# Altium schematic sheet CHIPHOME Y17BP01 W5500.SchDoc
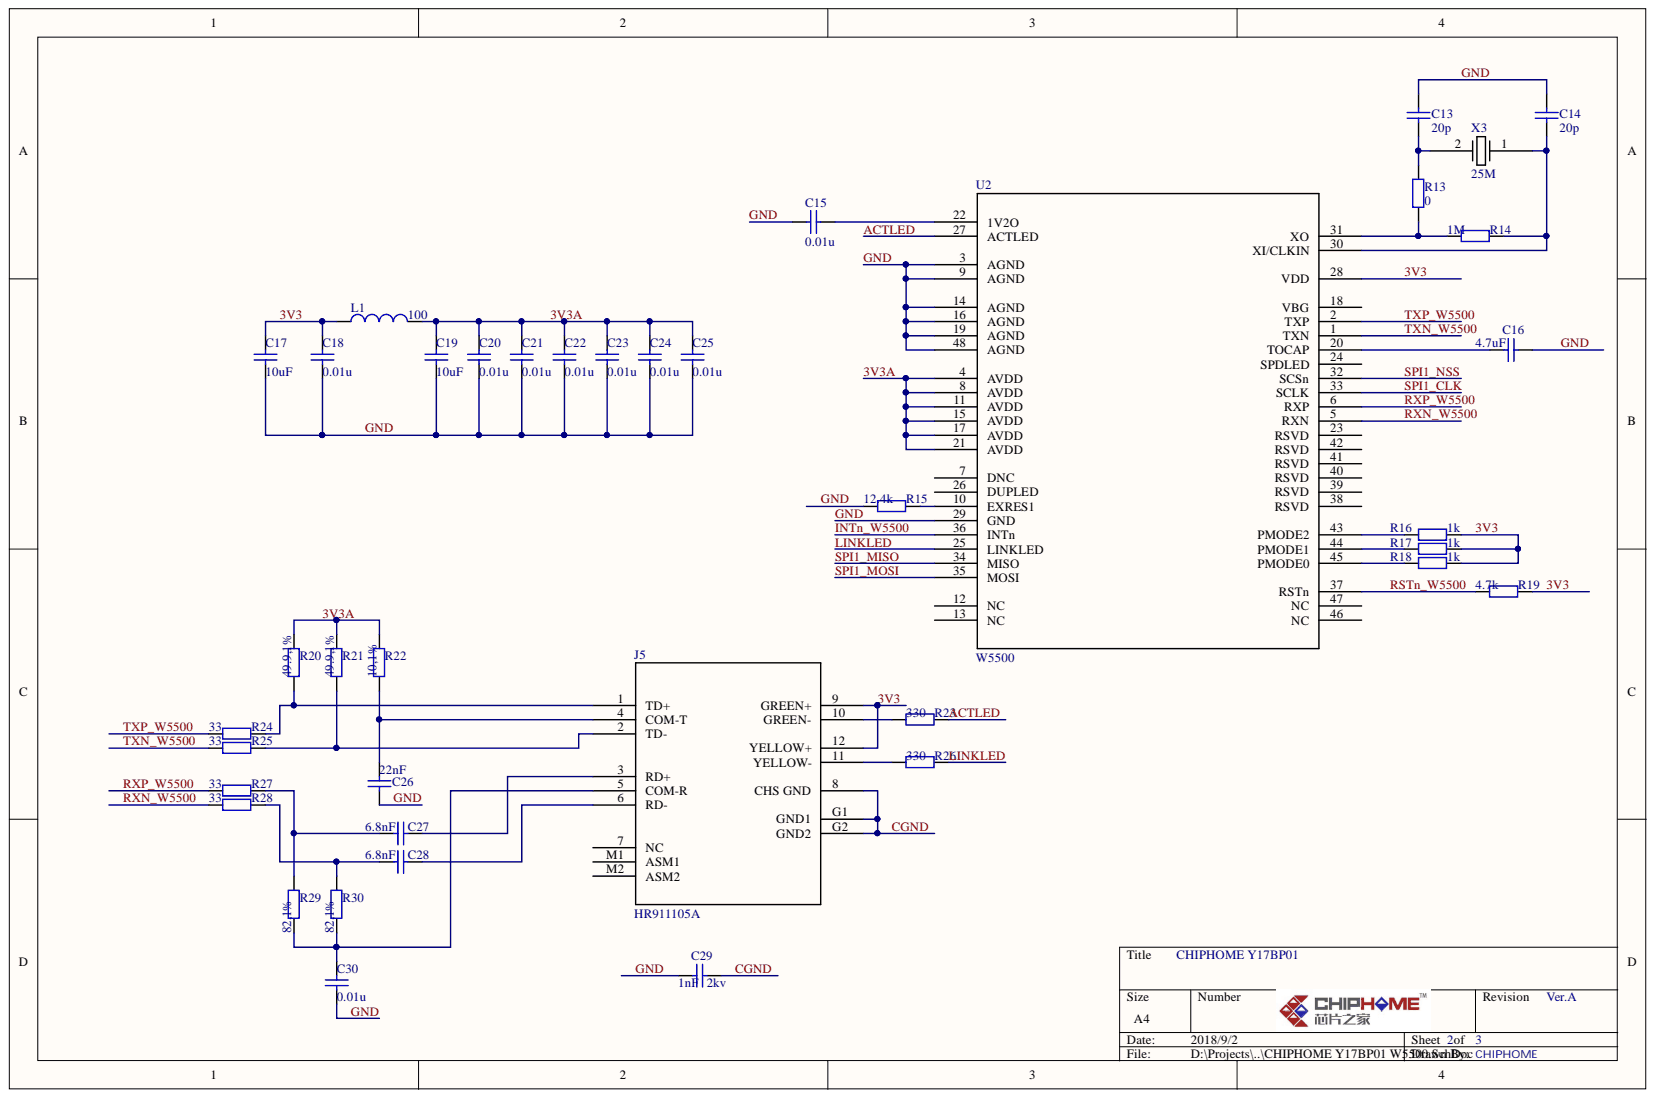
[diagram p0: CHIPHOME Y17BP01 W5500.SchDoc]

## Parts
C13  20p  [sc0603a]
C14  20p  [sc0603a]
C15  0.01u  [sc0603a]
C16  4.7uF  [sc0805a]
C17  10uF  [sc0805a]
C18  0.01u  [sc0603a]
C19  10uF  [sc0805a]
C20  0.01u  [sc0603a]
C21  0.01u  [sc0603a]
C22  0.01u  [sc0603a]
C23  0.01u  [sc0603a]
C24  0.01u  [sc0603a]
C25  0.01u  [sc0603a]
C26  22nF  [sc0603a]
C27  6.8nF  [sc0603a]
C28  6.8nF  [sc0603a]
C29  2kv  value 1nF  [sc1206a]
C30  0.01u  [sc0603a]
J5  HR911105A  [mj8-1521a]
L1  100  [sl0603a]
R13  0  [sr0603a]
R14  1M  [sr0603a]
R15  12.4k  [sr0603a]
R16  1k  [sr0603a]
R17  1k  [sr0603a]
R18  1k  [sr0603a]
R19  4.7k  [sr0603a]
R20  49.9,1%  [sr0603a]
R21  49.9,1%  [sr0603a]
R22  10,1%  [sr0603a]
R23  330  [sr0603a]
R24  33  [sr0603a]
R25  33  [sr0603a]
R26  330  [sr0603a]
R27  33  [sr0603a]
R28  33  [sr0603a]
R29  82,1%  [sr0603a]
R30  82,1%  [sr0603a]
U2  W5500  [qfp48-20-0909b]
X3  25M  [sx2-0412a]

## Nets
3V3: C17.2 C18.2 J5.9(GREEN+) J5.12(YELLOW+) L1.1 R16.1 R17.1 R18.1 R19.2 U2.28(VDD)
3V3A: C19.2 C20.2 C21.2 C22.2 C23.2 C24.2 C25.2 L1.2 R20.2 R21.2 R22.2 U2.4(AVDD) U2.8(AVDD) U2.11(AVDD) U2.15(AVDD) U2.17(AVDD) U2.21(AVDD)
ACTLED: R23.1 U2.27(ACTLED)
CGND: C29.2 J5.8(CHS_GND) J5.G1(GND1) J5.G2(GND2)
GND: C13.1 C14.1 C15.1 C16.2 C17.1 C18.1 C19.1 C20.1 C21.1 C22.1 C23.1 C24.1 C25.1 C26.2 C29.1 C30.2 R15.1 U2.3(AGND) U2.9(AGND) U2.14(AGND) U2.16(AGND) U2.19(AGND) U2.29(GND) U2.48(AGND)
INTn_W5500: U2.36(INTn)
LINKLED: R26.1 U2.25(LINKLED)
RSTn_W5500: R19.1 U2.37(RSTn)
RXN_W5500: R28.1 U2.5(RXN)
RXP_W5500: R27.1 U2.6(RXP)
SPI1_CLK: U2.33(SCLK)
SPI1_MISO: U2.34(MISO)
SPI1_MOSI: U2.35(MOSI)
SPI1_NSS: U2.32(SCSn)
TXN_W5500: R25.1 U2.1(TXN)
TXP_W5500: R24.1 U2.2(TXP)
NetC13_2: C13.2 R13.2 X3.2
NetC14_2: C14.2 R14.2 U2.30(XI/CLKIN) X3.1
NetC15_2: C15.2 U2.22(1V2O)
NetC16_1: C16.1 U2.20(TOCAP)
NetC26_1: C26.1 J5.4(COM-T) R22.1
NetC27_1: C27.1 R27.2 R29.2
NetC27_2: C27.2 J5.3(RD+)
NetC28_1: C28.1 R28.2 R30.2
NetC28_2: C28.2 J5.6(RD-)
NetC30_1: C30.1 J5.5(COM-R) R29.1 R30.1
NetJ5_1: J5.1(TD+) R20.1 R24.2
NetJ5_2: J5.2(TD-) R21.1 R25.2
NetJ5_10: J5.10(GREEN-) R23.2
NetJ5_11: J5.11(YELLOW-) R26.2
NetR13_1: R13.1 R14.1 U2.31(XO)
NetR15_2: R15.2 U2.10(EXRES1)
NetR16_2: R16.2 U2.43(PMODE2)
NetR17_2: R17.2 U2.44(PMODE1)
NetR18_2: R18.2 U2.45(PMODE0)

Not connected: J5 7(NC) M1(ASM1) M2(ASM2); U2 7(DNC) 12(NC) 13(NC) 18(VBG) 23(RSVD) 24(SPDLED) 26(DUPLED) 38(RSVD) 39(RSVD) 40(RSVD) 41(RSVD) 42(RSVD) 46(NC) 47(NC)

## Library data of the parts
C13-C30 = Cap: Capacitor; Component Kind: Standard; LatestRevisionDate: 17-Jul-2002; LatestRevisionNote: Re-released for DXP Platform.; Library Name: Miscellaneous Devices.IntLib; PackageReference: RAD-0.3; Published: 8-Jun-2000; Signal Integrity: Cap; Simulation: CAP; Publisher: Altium Limited
  C13 C14: Value: 20p
  C15 C18 C20-C25 C30: Value: 0.01u
  C16: Value: 4.7uF
  C17 C19: Value: 10uF
  C26: Value: 22nF
  C27 C28: Value: 6.8nF
J5 = HR911105A: Imported; CHcode: TFMEP000002; Designer: Chiphome; Component Kind: Standard; Device: HR911105A; Library Name: HR911105A.SchLib; RefDes: RefDes; Type: DEV; Value: Value
L1 = Inductor: Code_IEC: 1005; Code_JEITA: 0402; Component Kind: Standard; Description: Inductor; LatestRevisionDate: 29-May-2009; LatestRevisionNote: IPC-7351 Footprint Added.; Library Name: Miscellaneous Devices.IntLib; PackageDescription: Chip Inductor; PackageReference: 0402-A; Published: 8-Jun-2000; Signal Integrity: Inductor; Simulation: INDUCTOR; Value: 100; Publisher: Altium Limited
R13-R30 = Res2: Resistor; Component Kind: Standard; LatestRevisionDate: 17-Jul-2002; LatestRevisionNote: Re-released for DXP Platform.; Library Name: Miscellaneous Devices.IntLib; PackageReference: AXIAL-0.4; Published: 8-Jun-2000; Signal Integrity: Res; Simulation: RESISTOR; Publisher: Altium Limited
  R13: Value: 0
  R14: Value: 1M
  R15: Value: 12.4k
  R16-R18: Value: 1k
  R19: Value: 4.7k
  R20 R21: Value: 49.9,1%
  R22: Value: 10,1%
  R23 R26: Value: 330
  R24 R25 R27 R28: Value: 33
  R29 R30: Value: 82,1%
U2 = W5500: Imported; CHcode: CMUEA000374; Designer: CHIPHOME; Component Kind: Standard; Device: W5500; Library Name: w5500.schlib; RefDes: RefDes; Type: DEV; Value: Value
X3 = ABL-12.000MHZ-B2: Imported; CHcode: XTLDP000001; Designer: Chiphome; Component Kind: Standard; Device: ABL-12.000MHZ-B2; Library Name: ABL-12.000MHZ-B2.SchLib; RefDes: RefDes; Type: DEV; Value: 25M
